# Altium schematic sheet Sheet1.SchDoc(Sheet1)
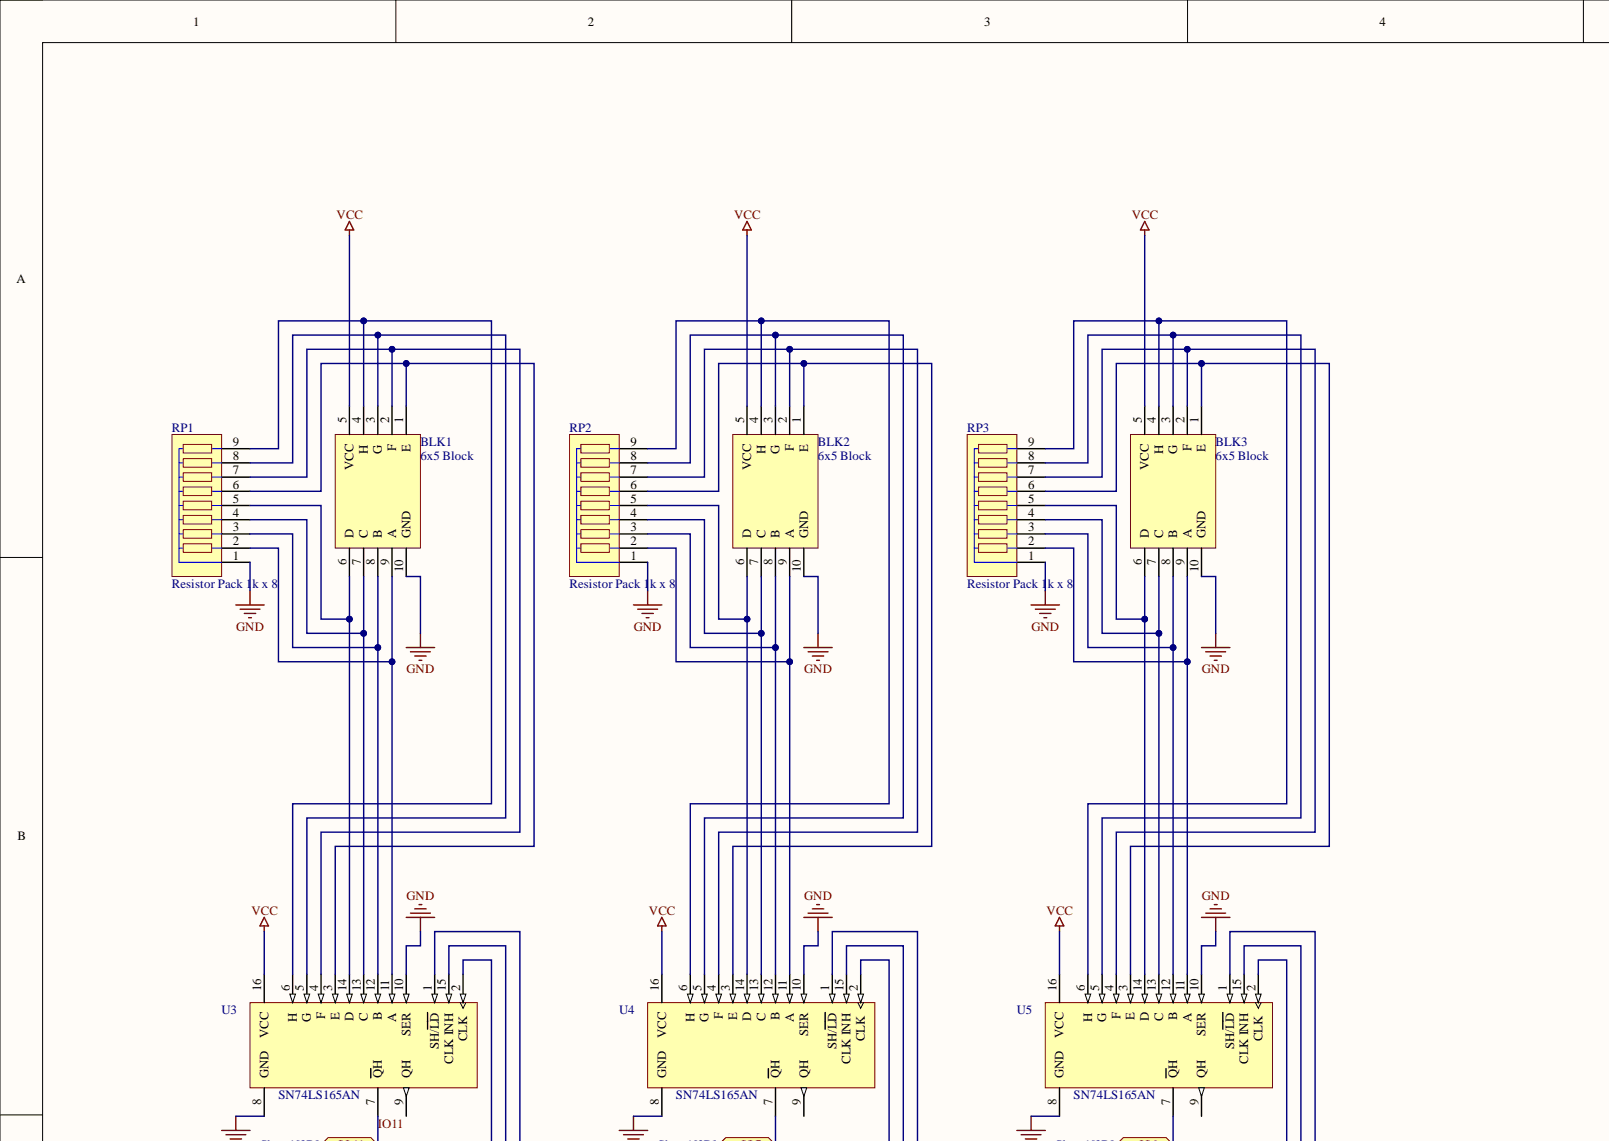
[diagram p0: Sheet1.SchDoc, top left]
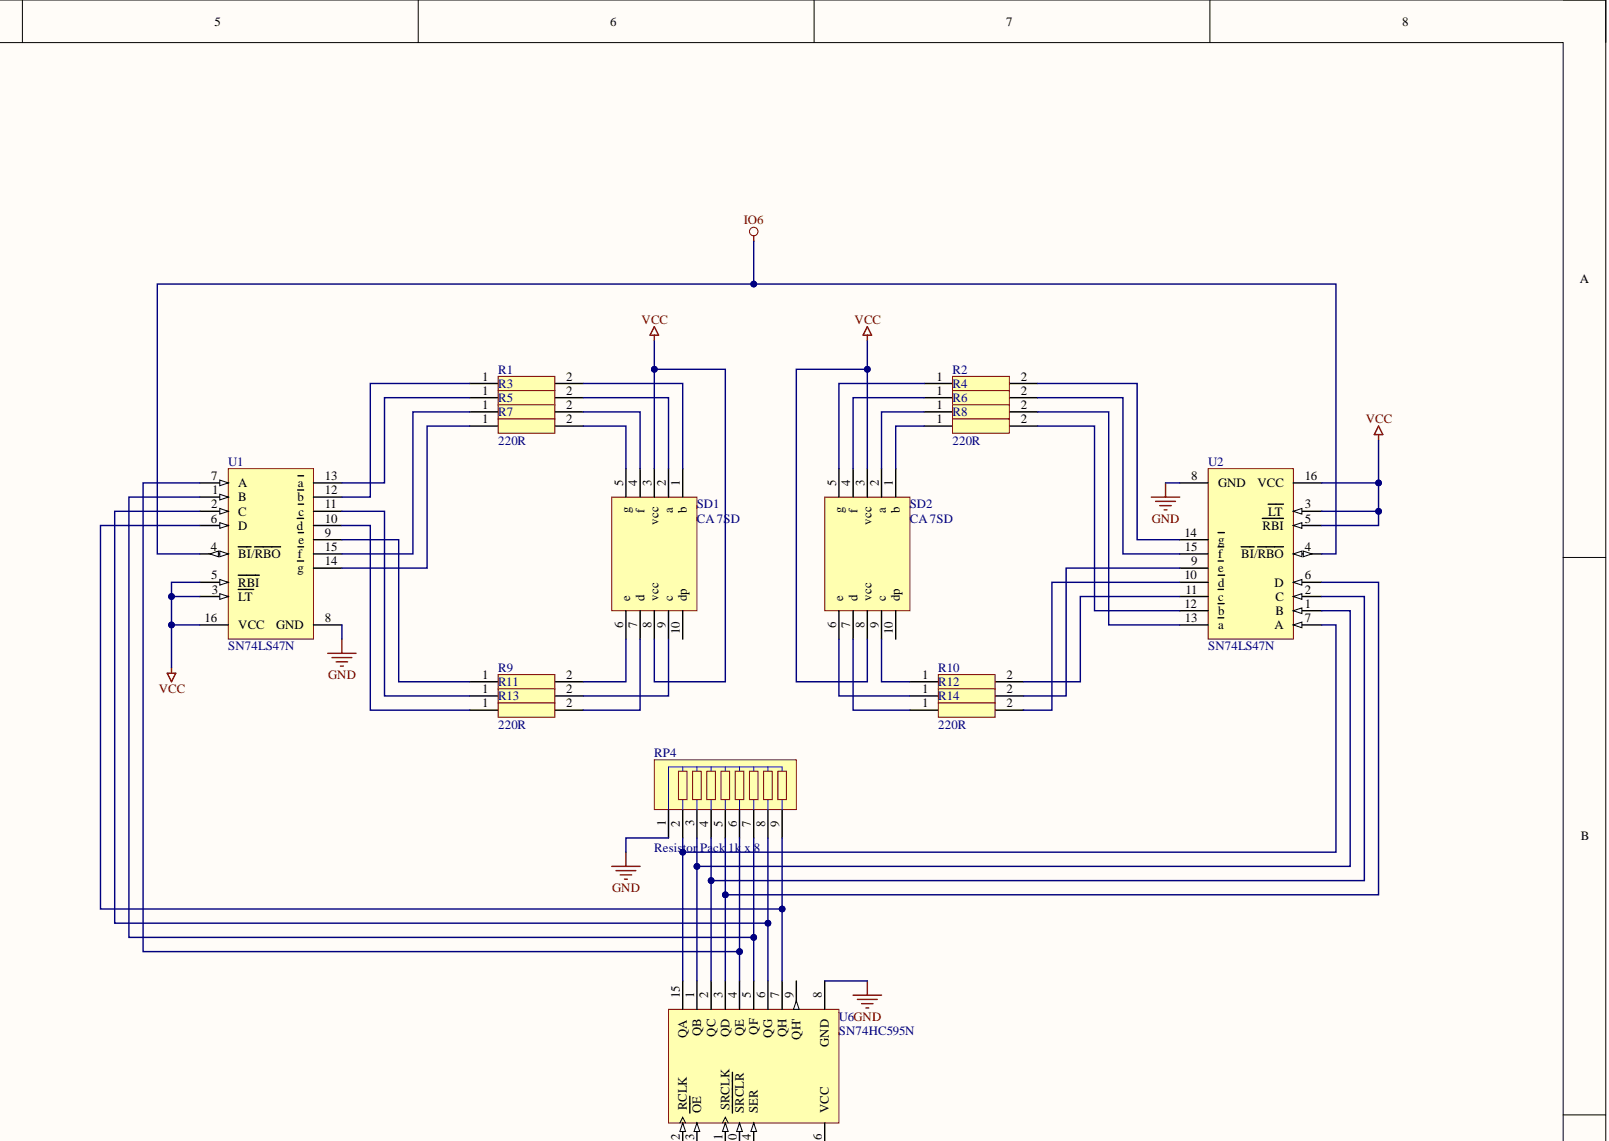
[diagram p1: Sheet1.SchDoc, top right]
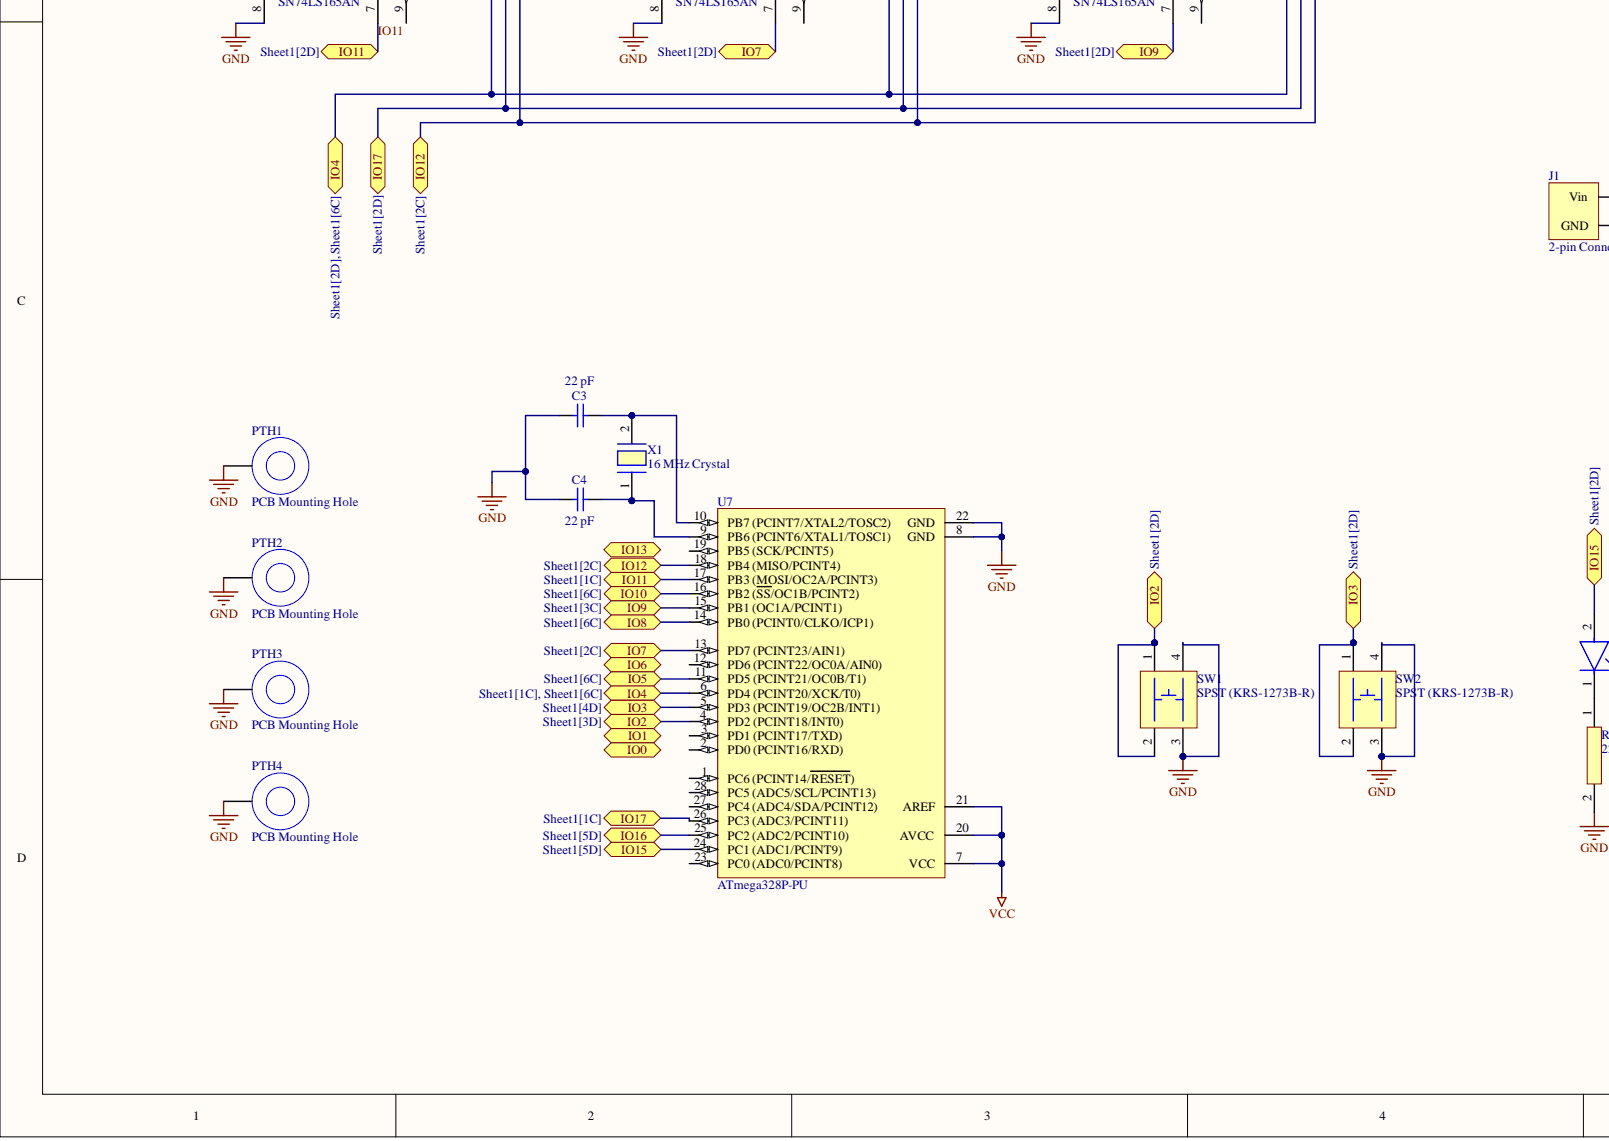
[diagram p2: Sheet1.SchDoc, bottom left]
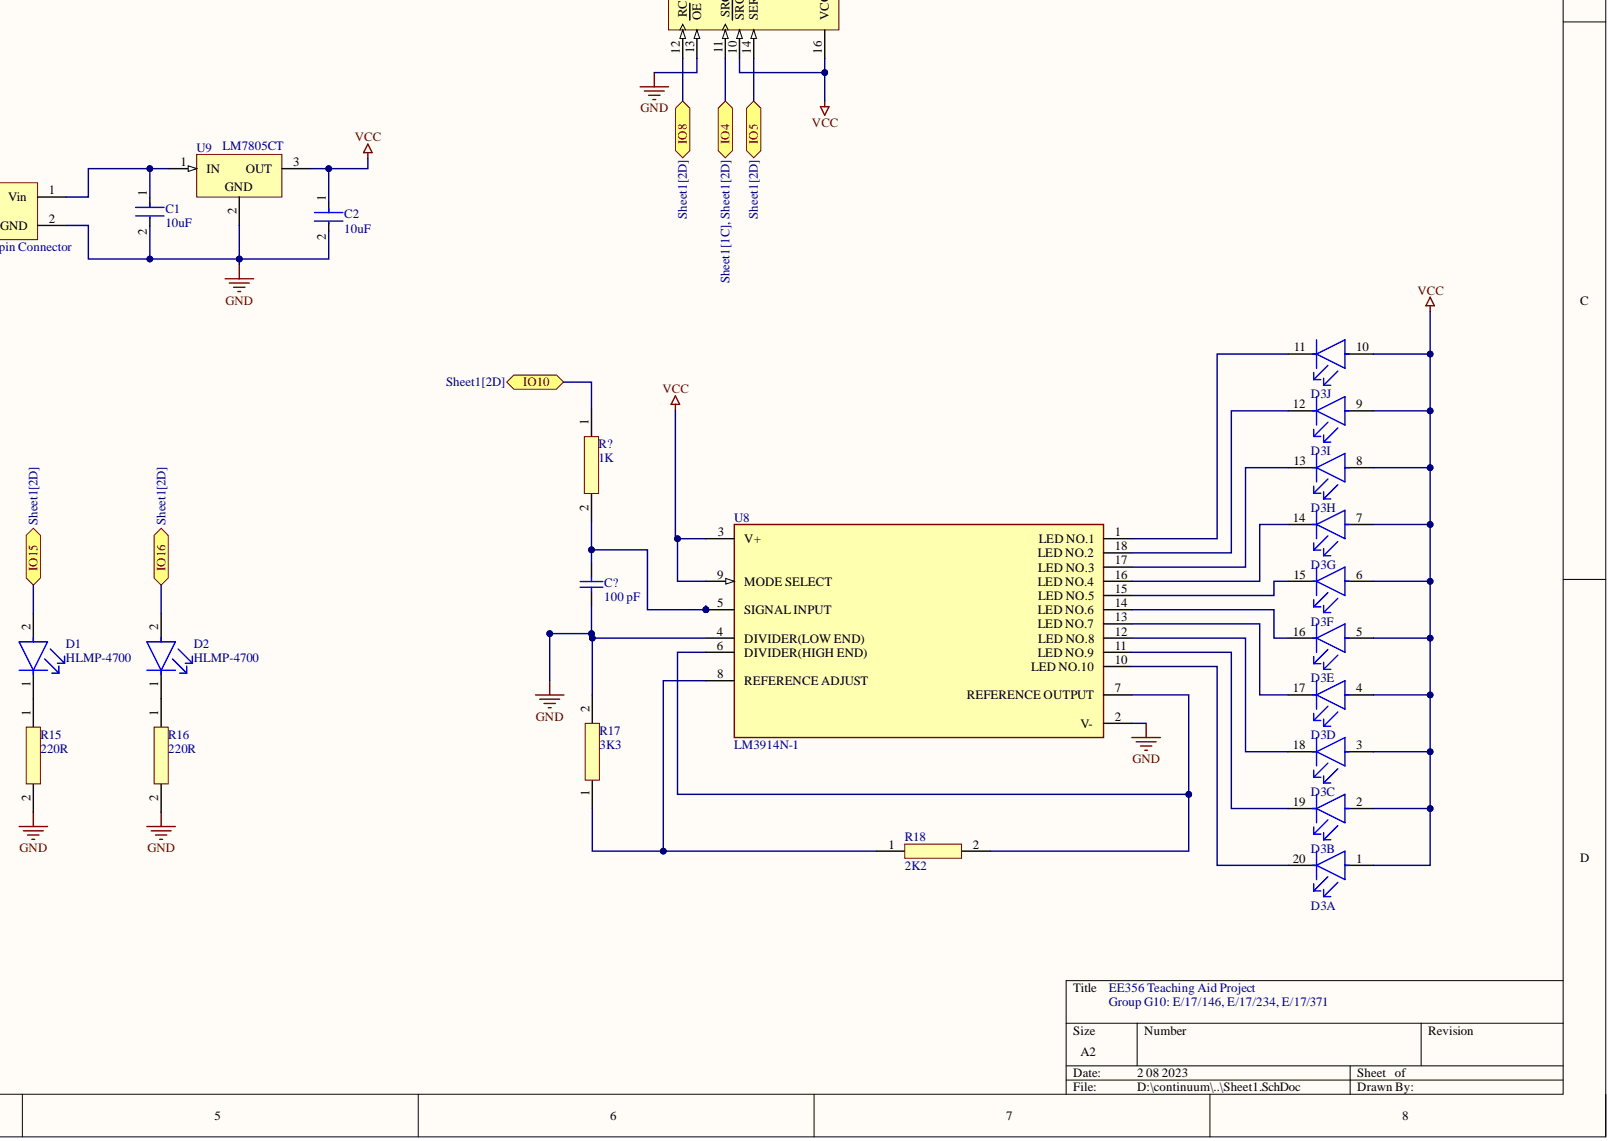
[diagram p3: Sheet1.SchDoc, bottom right]

## Parts
BLK1  6x5 Block  [Block_6x5]
BLK2  6x5 Block  [Block_6x5]
BLK3  6x5 Block  [Block_6x5]
C1  10uF  [FP-RCE3DB-MFG]
C2  10uF  [FP-RCE3DB-MFG]
C3  22 pF  [KEMT-C315-THT-2]
C4  22 pF  [KEMT-C315-THT-2]
C?  100 pF  [KEMT-C315-THT-2]
D1  HLMP-4700  [FP-HLMP-4700-MFG]
D2  HLMP-4700  [FP-HLMP-4700-MFG]
D3  SSA-LXB10HW-GF/LP  [FP-SSA-LXB10HW-GF-LP-MFG]  (value not drawn)  (parts A,B,C,D,E,F,G,H,I,J)
J1  2-pin Connector  [2-pin Connector]
PTH1  PCB Mounting Hole  [PTH 2mm]
PTH2  PCB Mounting Hole  [PTH 2mm]
PTH3  PCB Mounting Hole  [PTH 2mm]
PTH4  PCB Mounting Hole  [PTH 2mm]
R1  220R  [CFR_400mil]
R2  220R  [CFR_400mil]
R3  220R  [CFR_400mil]
R4  220R  [CFR_400mil]
R5  220R  [CFR_400mil]
R6  220R  [CFR_400mil]
R7  220R  [CFR_400mil]
R8  220R  [CFR_400mil]
R9  220R  [CFR_400mil]
R10  220R  [CFR_400mil]
R11  220R  [CFR_400mil]
R12  220R  [CFR_400mil]
R13  220R  [CFR_400mil]
R14  220R  [CFR_400mil]
R15  220R  [CFR_400mil]
R16  220R  [CFR_400mil]
R17  3K3  [CFR_400mil]
R18  2K2  [CFR_400mil]
R?  1K  [CFR_400mil]
RP1  Resistor Pack 1k x 8  [Resistor Pack 1k x 8]
RP2  Resistor Pack 1k x 8  [Resistor Pack 1k x 8]
RP3  Resistor Pack 1k x 8  [Resistor Pack 1k x 8]
RP4  Resistor Pack 1k x 8  [Resistor Pack 1k x 8]
SD1  CA 7SD  [CA 7SD]
SD2  CA 7SD  [CA 7SD]
SW1  SPST (KRS-1273B-R)  Throw Configuration: SPST  [SPST KRS-1273B-R]
SW2  SPST (KRS-1273B-R)  Throw Configuration: SPST  [SPST KRS-1273B-R]
U1  SN74LS47N  [N0016A]
U2  SN74LS47N  [N0016A]
U3  SN74LS165AN  [N0016A]
U4  SN74LS165AN  [N0016A]
U5  SN74LS165AN  [N0016A]
U6  SN74HC595N  [N0016A]
U7  ATmega328P-PU  [28P3]
U8  LM3914N-1  [FP-NFK0018A-MFG]
U9  LM7805CT  [FAIR-TO-220-3]
X1  16 MHz Crystal  [Crystal Osc TH]

## Ports
IO0, IO1, IO2, IO3, IO4, IO5, IO6, IO7, IO8, IO9, IO10, IO11, IO12, IO13, IO15, IO16, IO17

## Nets
GND: BLK1.10(GND) BLK2.10(GND) BLK3.10(GND) C1.2 C2.2 C3.1 C4.1 C?.1 J1.2 PTH1.1 PTH2.1 PTH3.1 PTH4.1 R15.2 R16.2 R17.2 RP1.1 RP2.1 RP3.1 RP4.1 SW1.3 SW1.4 SW2.3 SW2.4 U1.8(GND) U2.8(GND) U3.8(GND) U3.10(SER) U4.8(GND) U4.10(SER) U5.8(GND) U5.10(SER) U6.8(GND) U6.13(OE) U7.8(GND) U7.22(GND) U8.2(V-) U8.4(DIVIDER(LOW_END)) U9.2
IO6: U1.4(BI/RBO) U2.4(BI/RBO)
VCC: BLK1.5(VCC) BLK2.5(VCC) BLK3.5(VCC) C2.1 D3.1 D3.2 D3.3 D3.4 D3.5 D3.6 D3.7 D3.8 D3.9 D3.10 SD1.3(vcc) SD1.8(vcc) SD2.3(vcc) SD2.8(vcc) U1.3(LT) U1.5(RBI) U1.16(VCC) U2.3(LT) U2.5(RBI) U2.16(VCC) U3.16(VCC) U4.16(VCC) U5.16(VCC) U6.10(SRCLR) U6.16(VCC) U7.7(VCC) U7.20(AVCC) U7.21(AREF) U8.3(V+) U8.9(MODE_SELECT) U9.3
IO2: SW1.1 SW1.2 U7.4(PD2_(PCINT18/INT0)) ; port IO2
IO3: SW2.1 SW2.2 U7.5(PD3_(PCINT19/OC2B/INT1)) ; port IO3
IO4: U3.2(CLK) U4.2(CLK) U5.2(CLK) U6.11(SRCLK) U7.6(PD4_(PCINT20/XCK/T0)) ; port IO4
IO5: U6.14(SER) U7.11(PD5_(PCINT21/OC0B/T1)) ; port IO5
IO7: U4.7(QH) U7.13(PD7_(PCINT23/AIN1)) ; port IO7
IO8: U6.12(RCLK) U7.14(PB0_(PCINT0/CLKO/ICP1)) ; port IO8
IO9: U5.7(QH) U7.15(PB1_(OC1A/PCINT1)) ; port IO9
IO10: R?.1 U7.16(PB2_(SS/OC1B/PCINT2)) ; port IO10
IO11: U3.7(QH) U7.17(PB3_(MOSI/OC2A/PCINT3)) ; port IO11
IO12: U3.1(SH/LD) U4.1(SH/LD) U5.1(SH/LD) U7.18(PB4_(MISO/PCINT4)) ; port IO12
IO15: D1.2 U7.24(PC1_(ADC1/PCINT9)) ; port IO15
IO16: D2.2 U7.25(PC2_(ADC2/PCINT10)) ; port IO16
IO17: U3.15(CLK_INH) U4.15(CLK_INH) U5.15(CLK_INH) U7.26(PC3_(ADC3/PCINT11)) ; port IO17
NetBLK1_1: BLK1.1(E) RP1.6 U3.3(E)
NetBLK1_2: BLK1.2(F) RP1.7 U3.4(F)
NetBLK1_3: BLK1.3(G) RP1.8 U3.5(G)
NetBLK1_4: BLK1.4(H) RP1.9 U3.6(H)
NetBLK1_6: BLK1.6(D) RP1.5 U3.14(D)
NetBLK1_7: BLK1.7(C) RP1.4 U3.13(C)
NetBLK1_8: BLK1.8(B) RP1.3 U3.12(B)
NetBLK1_9: BLK1.9(A) RP1.2 U3.11(A)
NetBLK2_1: BLK2.1(E) RP2.6 U4.3(E)
NetBLK2_2: BLK2.2(F) RP2.7 U4.4(F)
NetBLK2_3: BLK2.3(G) RP2.8 U4.5(G)
NetBLK2_4: BLK2.4(H) RP2.9 U4.6(H)
NetBLK2_6: BLK2.6(D) RP2.5 U4.14(D)
NetBLK2_7: BLK2.7(C) RP2.4 U4.13(C)
NetBLK2_8: BLK2.8(B) RP2.3 U4.12(B)
NetBLK2_9: BLK2.9(A) RP2.2 U4.11(A)
NetBLK3_1: BLK3.1(E) RP3.6 U5.3(E)
NetBLK3_2: BLK3.2(F) RP3.7 U5.4(F)
NetBLK3_3: BLK3.3(G) RP3.8 U5.5(G)
NetBLK3_4: BLK3.4(H) RP3.9 U5.6(H)
NetBLK3_6: BLK3.6(D) RP3.5 U5.14(D)
NetBLK3_7: BLK3.7(C) RP3.4 U5.13(C)
NetBLK3_8: BLK3.8(B) RP3.3 U5.12(B)
NetBLK3_9: BLK3.9(A) RP3.2 U5.11(A)
NetC1_1: C1.1 J1.1 U9.1
NetC3_2: C3.2 U7.10(PB7_(PCINT7/XTAL2/TOSC2)) X1.2
NetC4_2: C4.2 U7.9(PB6_(PCINT6/XTAL1/TOSC1)) X1.1
NetC?_2: C?.2 R?.2 U8.5(SIGNAL_INPUT)
NetD1_1: D1.1 R15.1
NetD2_1: D2.1 R16.1
NetD3_11: D3.11 U8.1(LED_NO.1)
NetD3_12: D3.12 U8.18(LED_NO.2)
NetD3_13: D3.13 U8.17(LED_NO.3)
NetD3_14: D3.14 U8.16(LED_NO.4)
NetD3_15: D3.15 U8.15(LED_NO.5)
NetD3_16: D3.16 U8.14(LED_NO.6)
NetD3_17: D3.17 U8.13(LED_NO.7)
NetD3_18: D3.18 U8.12(LED_NO.8)
NetD3_19: D3.19 U8.11(LED_NO.9)
NetD3_20: D3.20 U8.10(LED_NO.10)
NetR1_1: R1.1 U1.12(b)
NetR1_2: R1.2 SD1.1(b)
NetR2_1: R2.1 SD2.5(g)
NetR2_2: R2.2 U2.14(g)
NetR3_1: R3.1 U1.13(a)
NetR3_2: R3.2 SD1.2(a)
NetR4_1: R4.1 SD2.4(f)
NetR4_2: R4.2 U2.15(f)
NetR5_1: R5.1 U1.15(f)
NetR5_2: R5.2 SD1.4(f)
NetR6_1: R6.1 SD2.2(a)
NetR6_2: R6.2 U2.13(a)
NetR7_1: R7.1 U1.14(g)
NetR7_2: R7.2 SD1.5(g)
NetR8_1: R8.1 SD2.1(b)
NetR8_2: R8.2 U2.12(b)
NetR9_1: R9.1 U1.9(e)
NetR9_2: R9.2 SD1.6(e)
NetR10_1: R10.1 SD2.9(c)
NetR10_2: R10.2 U2.11(c)
NetR11_1: R11.1 U1.11(c)
NetR11_2: R11.2 SD1.9(c)
NetR12_1: R12.1 SD2.6(e)
NetR12_2: R12.2 U2.9(e)
NetR13_1: R13.1 U1.10(d)
NetR13_2: R13.2 SD1.7(d)
NetR14_1: R14.1 SD2.7(d)
NetR14_2: R14.2 U2.10(d)
NetR17_1: R17.1 R18.1 U8.8(REFERENCE_ADJUST)
NetR18_2: R18.2 U8.6(DIVIDER(HIGH_END)) U8.7(REFERENCE_OUTPUT)
NetRP4_2: RP4.2 U2.7(A) U6.15(QA)
NetRP4_3: RP4.3 U2.1(B) U6.1(QB)
NetRP4_4: RP4.4 U2.2(C) U6.2(QC)
NetRP4_5: RP4.5 U2.6(D) U6.3(QD)
NetRP4_6: RP4.6 U1.7(A) U6.4(QE)
NetRP4_7: RP4.7 U1.1(B) U6.5(QF)
NetRP4_8: RP4.8 U1.2(C) U6.6(QG)
NetRP4_9: RP4.9 U1.6(D) U6.7(QH)
N00001: ; port IO13
N00002: ; port IO1
N00003: ; port IO0
N00004: ; port IO6

Not connected: SD1 10(dp); SD2 10(dp); U3 9(QH); U4 9(QH); U5 9(QH); U6 9(QH'); U7 1(PC6_(PCINT14/RESET)) 2(PD0_(PCINT16/RXD)) 3(PD1_(PCINT17/TXD)) 12(PD6_(PCINT22/OC0A/AIN0)) 19(PB5_(SCK/PCINT5)) 23(PC0_(ADC0/PCINT8)) 27(PC4_(ADC4/SDA/PCINT12)) 28(PC5_(ADC5/SCL/PCINT13))

## Library data of the parts
BLK1-BLK3 = Block_6x5: Component Kind: Standard; Library Name: Schlib1.SchLib
C1 C2 = CMP-06035-033894-1: Cap Ceramic 10uF 25V X7R ±10% Radial 2.5mm +125°C Automotive Bulk (RCER71E106K3A2H03B); Lead Diameter: 0.5mm; Lead/Base Style: Straight; Capacitance: 10uF; Case/Package: Radial; Component Kind: Standard; Dielectric: X7R; Height: 5mm; Height - Seated (Max): 0.197inch; Lead Pitch: 0.098inch; Length: 0.217inch; Library Name: Altium Content Vault; Max Operating Temperature: 125°C; Min Operating Temperature: -55°C; Mount: Through Hole; Package Quantity: 500; Packaging: Bulk; Ratings: AEC-Q200; Resistor Type: Ceramic; Termination: Radial; Tolerance: 10%; Voltage Rating: 25V; Voltage Rating (DC): 25V; Width: 0.157inch
C3 C4 = CMP-1664-00008-1: Capacitor, Ceramic, 22 pF, +/-10 %, 100 V, -55 to 125 degC, 2-Pin THD, RoHS, Bulk; Case\Package: TO-220; Max Input Voltage: 40 V; Max Ouput Current: 1.5 A; Min Input Voltage: 4.2 V; Output Voltage: 1.2 V; Outputs: 1; Component Kind: Standard; ComponentLink1Description: Manufacturer URL; ComponentLink1URL: http://www.kemet.com/; ComponentLink2Description: Datasheet; ComponentLink2URL: http://capacitoredge.kemet.com/capedge2/DataSheet/Datasheet-C315C220K1G5TA.pdf?pn=C315C220K1G5TA{BULK}; DatasheetVersion: Aug-16; Height: 9.28 mm; Length: 10.28 mm; Library Name: Altium Content Vault; Max Operating Temperature: 125 degC; Min Operating Temperature: 0 degC; Mount: Through Hole; Output Current: 1.5 A; Package Quantity: 50; PackageDescription: 2-Pin Through Hole Device, Body 3.81 x 2.54 mm; PackageReference: C315-THT-2; Packaging: Rail/Tube; Pins: 3; Radiation Hardening: No; REACH SVHC: No SVHC; RoHSCompliant: true; Weight: 0.08113 oz; Width: 4.82 mm
C? = CMP-1664-00008-1: Capacitor, Ceramic, 100 pF, +/-10 %, 100 V, -55 to 125 degC, 2-Pin THD, RoHS, Bulk; Case\Package: TO-220; Max Input Voltage: 40 V; Max Ouput Current: 1.5 A; Min Input Voltage: 4.2 V; Output Voltage: 1.2 V; Outputs: 1; Component Kind: Standard; ComponentLink1Description: Manufacturer URL; ComponentLink1URL: http://www.kemet.com/; ComponentLink2Description: Datasheet; ComponentLink2URL: http://capacitoredge.kemet.com/capedge2/DataSheet/Datasheet-C315C220K1G5TA.pdf?pn=C315C220K1G5TA{BULK}; DatasheetVersion: Aug-16; Height: 9.28 mm; Length: 10.28 mm; Library Name: Altium Content Vault; Max Operating Temperature: 125 degC; Min Operating Temperature: 0 degC; Mount: Through Hole; Output Current: 1.5 A; Package Quantity: 50; PackageDescription: 2-Pin Through Hole Device, Body 3.81 x 2.54 mm; PackageReference: C315-THT-2; Packaging: Rail/Tube; Pins: 3; Radiation Hardening: No; REACH SVHC: No SVHC; RoHSCompliant: true; Weight: 0.08113 oz; Width: 4.82 mm
D1 D2 = CMP-18399-000008-1: LED RED DIFFUSED T-1 3/4 T/H; Manufacturer: Broadcom; Forward Current: 2mA; Forward Voltage: 1.7V; Led Orientation: Straight; Luminous Intensity: 2.3mcd; Peak Emmision Wavelength: 635nm; Power Dissipation: 14mW; Reverse Voltage: 5V; Viewing Angle: 50°; Wavelength: 626nm; Automotive: No; Category: Diode; Colour: Red; Component Kind: Standard; ComponentLink2Description: Datasheet; ComponentLink2URL: https://docs.broadcom.com/docs/AV02-1557EN; Device Class L1: Optoelectronics; Device Class L2: LEDs; Device Class L3: unset; Digikey Description: LED RED DIFFUSED T-1 3/4 T/H; Lead Free: Yes; Library Name: Altium Content Vault; Manufacturer Part Number: HLMP-4700; Mouser Description: LED; Red; 2.3mcd; 0.24In.Dia.; T-1-3/4; 500mA; 1.7V; 635nm; 50deg; 5V; 24mW; -55degC;; Octopart: https://octopart.com/hlmp-4700-broadcom-71130526; Package: HLMP-4700; RoHS: TRUE; Temperature: -40°C to +100°C; Temperature Range High: +100°C; Temperature Range Low: -40°C
D3 = CMP-57188-000001-1: LED ARRAY 10X25MM 10SEG RED DIFF; Manufacturer: lumex; Display Type: Bar Graph; Forward Current: 20mA; Forward Voltage: 2V; Luminous Intensity: 500mcd; Power Dissipation: 105mW; Reverse Voltage: 5V; Viewing Angle: 160°; Automotive: No; Category: Diode; Component Kind: Standard; ComponentLink2Description: Datasheet; ComponentLink2URL: https://www.lumex.com/spec/SSA-LXB10HW-GF-LP.pdf; Device Class L1: Optoelectronics; Device Class L2: Displays; Device Class L3: LED Displays; Digikey Description: LED ARRAY 10X25MM 10SEG RED DIFF; Height: 7.05mm; Lead Free: Yes; Library Name: Altium Content Vault; Manufacturer Part Number: SSA-LXB10HW-GF/LP; Mouser Description: LED Bars and Arrays 10 Segment Red; Octopart: https://octopart.com/ssa-lxb10hw-gf%2Flp-lumex-548235; Package: SSA-LXB10HW-GF/LP; RoHS: TRUE; Temperature: -40°C to +85°C; Temperature Range High: +85°C; Temperature Range Low: -40°C; Test Current: 20mA
J1 = PPTC021LFBN-RC: Female, 2C, Straight, .100"CC; 3.20mm Tail, No Mt. 100u" Tin; PBT, Phos Bronze, 105 C; Manufacturer: Sullins; Connector Type: Header; Number of Positions: 2; Pitch: 0.1inch; Category: Connectors; Color: Black; Component Kind: Standard; ComponentLink1Description: https://media.digikey.com/pdf/Data%20Sheets/Sullins%20PDFs/Female_Headers.100_DS.pdf?src-supplier=Digi-Key; ComponentLink1URL: https://media.digikey.com/pdf/Data%20Sheets/Sullins%20PDFs/Female_Headers.100_DS.pdf?src-supplier=Digi-Key; ComponentLink2Description: http://www.sullinscorp.com/catalogs/78_Page80-81_HEADER_.100_2.54mm_FEMALE.pdf?src-supplier=Future+Electronics; ComponentLink2URL: http://www.sullinscorp.com/catalogs/78_Page80-81_HEADER_.100_2.54mm_FEMALE.pdf?src-supplier=Future+Electronics; Contact Material: Bronze; Contact Plating: Tin; Contact Type: Female Socket; Height: 8.5mm; Housing Material: Plastic; Lead Free: Lead Free; Length: 5.58mm; Library Name: Schlib1.SchLib; Manufacturer Part Number: PPTC021LFBN-RC; Mount: Through Hole; Number of Rows: 1; Package Quantity: 1000; Packaging: Bulk; Pricing 1: 1=0.32000, 10=0.27500, 100=0.21390, 500=0.18332, 1000=0.15278, 5000=0.13095, 10000=0.12610 (USD); RoHS: Yes; RoHS Compliant: Yes; Stock 1: 86332; Supplier 1: Digi-Key; Supplier Part Number 1: S7000-ND; Termination: Solder; Width: 2.5mm
PTH1-PTH4 = PTH: Component Kind: Standard; Library Name: Schlib1.SchLib
R1-R16 = CFR-25JB-52-220R: General Type Carbon Film Resistor 220Ohm 1/4W 5% Axial Bulk; Manufacturer: Yageo; Max Power Dissipation: 250mW; Temperature Coefficient: 500ppm/°C; Case/Package: Axial; Category: Through-Hole Resistors; Component Kind: Standard; ComponentLink1Description: http://www.mouser.com/ds/2/447/Yageo%20LR_CFR_2013-540723.pdf?src-supplier=Mouser; ComponentLink1URL: http://www.mouser.com/ds/2/447/Yageo%20LR_CFR_2013-540723.pdf?src-supplier=Mouser; ComponentLink2Description: http://www.yageo.com/NewPortal/yageodocoutput?fileName=/pdf/throughhole/Yageo_LR_CFR_2013.pdf&src-supplier=Digi-Key; ComponentLink2URL: http://www.yageo.com/NewPortal/yageodocoutput?fileName=/pdf/throughhole/Yageo_LR_CFR_2013.pdf&src-supplier=Digi-Key; Length: 0.248inch; Library Name: Schlib1.SchLib; Manufacturer Part Number: CFR-25JB-52-220R; Max Operating Temperature: 155°C; Min Operating Temperature: -55°C; Number of Terminations: 2; Package Quantity: 200; Packaging: Bulk; Pricing 1: 1=0.10000, 10=0.06800, 25=0.04680, 50=0.03640, 100=0.02600, 250=0.02028, 500=0.01612, 1000=0.01144, 5000=0.00884 (USD); Resistance: 220R; Resistor Type: Carbon Film; RoHS: Yes; RoHS Compliant: Yes; Series: Carbon Film; Stock 1: 1583; Supplier 1: Digi-Key; Supplier Part Number 1: 220QBK-ND; Tolerance: 5%
R17 = CFR-25JB-52-3K3: General Type Carbon Film Resistor 3.3kOhm 1/4W 5% Axial Bulk; Manufacturer: Yageo; Manufacturer Part Number: CFR-25JB-52-220R; Max Power Dissipation: 250mW; Resistance: 220R; Temperature Coefficient: 500ppm/°C; Case/Package: Axial; Category: Through-Hole Resistors; Component Kind: Standard; ComponentLink1Description: http://www.mouser.com/ds/2/447/Yageo%20LR_CFR_2013-540723.pdf?src-supplier=Mouser; ComponentLink1URL: http://www.mouser.com/ds/2/447/Yageo%20LR_CFR_2013-540723.pdf?src-supplier=Mouser; ComponentLink2Description: http://www.yageo.com/NewPortal/yageodocoutput?fileName=/pdf/throughhole/Yageo_LR_CFR_2013.pdf&src-supplier=Digi-Key; ComponentLink2URL: http://www.yageo.com/NewPortal/yageodocoutput?fileName=/pdf/throughhole/Yageo_LR_CFR_2013.pdf&src-supplier=Digi-Key; Length: 0.248inch; Library Name: Schlib1.SchLib; Max Operating Temperature: 155°C; Min Operating Temperature: -55°C; Number of Terminations: 2; Package Quantity: 200; Packaging: Bulk; Pricing 1: 1=0.10000, 10=0.06800, 25=0.04680, 50=0.03640, 100=0.02600, 250=0.02028, 500=0.01612, 1000=0.01144, 5000=0.00884 (USD); Resistor Type: Carbon Film; RoHS: Yes; RoHS Compliant: Yes; Series: Carbon Film; Stock 1: 1583; Supplier 1: Digi-Key; Supplier Part Number 1: 220QBK-ND; Tolerance: 5%
R18 = CFR-25JB-52-2K2: General Type Carbon Film Resistor 2.2kOhm 1/4W 5% Axial Bulk; Manufacturer: Yageo; Manufacturer Part Number: CFR-25JB-52-220R; Max Power Dissipation: 250mW; Resistance: 220R; Temperature Coefficient: 500ppm/°C; Case/Package: Axial; Category: Through-Hole Resistors; Component Kind: Standard; ComponentLink1Description: http://www.mouser.com/ds/2/447/Yageo%20LR_CFR_2013-540723.pdf?src-supplier=Mouser; ComponentLink1URL: http://www.mouser.com/ds/2/447/Yageo%20LR_CFR_2013-540723.pdf?src-supplier=Mouser; ComponentLink2Description: http://www.yageo.com/NewPortal/yageodocoutput?fileName=/pdf/throughhole/Yageo_LR_CFR_2013.pdf&src-supplier=Digi-Key; ComponentLink2URL: http://www.yageo.com/NewPortal/yageodocoutput?fileName=/pdf/throughhole/Yageo_LR_CFR_2013.pdf&src-supplier=Digi-Key; Length: 0.248inch; Library Name: Schlib1.SchLib; Max Operating Temperature: 155°C; Min Operating Temperature: -55°C; Number of Terminations: 2; Package Quantity: 200; Packaging: Bulk; Pricing 1: 1=0.10000, 10=0.06800, 25=0.04680, 50=0.03640, 100=0.02600, 250=0.02028, 500=0.01612, 1000=0.01144, 5000=0.00884 (USD); Resistor Type: Carbon Film; RoHS: Yes; RoHS Compliant: Yes; Series: Carbon Film; Stock 1: 1583; Supplier 1: Digi-Key; Supplier Part Number 1: 220QBK-ND; Tolerance: 5%
R? = CFR-25JB-52-3K3: General Type Carbon Film Resistor 1kOhm 1/4W 5% Axial Bulk; Manufacturer: Yageo; Manufacturer Part Number: CFR-25JB-52-220R; Max Power Dissipation: 250mW; Resistance: 220R; Temperature Coefficient: 500ppm/°C; Case/Package: Axial; Category: Through-Hole Resistors; Component Kind: Standard; ComponentLink1Description: http://www.mouser.com/ds/2/447/Yageo%20LR_CFR_2013-540723.pdf?src-supplier=Mouser; ComponentLink1URL: http://www.mouser.com/ds/2/447/Yageo%20LR_CFR_2013-540723.pdf?src-supplier=Mouser; ComponentLink2Description: http://www.yageo.com/NewPortal/yageodocoutput?fileName=/pdf/throughhole/Yageo_LR_CFR_2013.pdf&src-supplier=Digi-Key; ComponentLink2URL: http://www.yageo.com/NewPortal/yageodocoutput?fileName=/pdf/throughhole/Yageo_LR_CFR_2013.pdf&src-supplier=Digi-Key; Length: 0.248inch; Library Name: Schlib1.SchLib; Max Operating Temperature: 155°C; Min Operating Temperature: -55°C; Number of Terminations: 2; Package Quantity: 200; Packaging: Bulk; Pricing 1: 1=0.10000, 10=0.06800, 25=0.04680, 50=0.03640, 100=0.02600, 250=0.02028, 500=0.01612, 1000=0.01144, 5000=0.00884 (USD); Resistor Type: Carbon Film; RoHS: Yes; RoHS Compliant: Yes; Series: Carbon Film; Stock 1: 1583; Supplier 1: Digi-Key; Supplier Part Number 1: 220QBK-ND; Tolerance: 5%
RP1-RP4 = 4309R-101-102LF: Res Thick Film NET 1K Ohm 2% 1.13W ±100ppm/°C BUS Molded 9-Pin SIP Pin Thru-Hole; Manufacturer: Bourns; Circuit Type: Bussed; Number of Elements: 8; Number of Terminals/Pins: 9; Power Per Element: 200mW; Resistance: 1kR; Voltage Rating: 100V; Case/Package: SIP; Category: Resistor Arrays; Component Kind: Standard; ComponentLink1Description: https://datasheet.ciiva.com/1132/1639105-1132861.pdf?src-supplier=Element14; ComponentLink1URL: https://datasheet.ciiva.com/1132/1639105-1132861.pdf?src-supplier=Element14; ComponentLink2Description: https://datasheet.ciiva.com/3264/4300r-3264093.pdf?src-supplier=Avnet; ComponentLink2URL: https://datasheet.ciiva.com/3264/4300r-3264093.pdf?src-supplier=Avnet; ComponentLink3Description: https://datasheet.ciiva.com/6494/4300r-6494366.pdf?src-supplier=Digi-Key; ComponentLink3URL: https://datasheet.ciiva.com/6494/4300r-6494366.pdf?src-supplier=Digi-Key; ComponentLink4Description: https://datasheet.ciiva.com/14583/70154247-14583487.pdf?src-supplier=Allied+Electronics; ComponentLink4URL: https://datasheet.ciiva.com/14583/70154247-14583487.pdf?src-supplier=Allied+Electronics; Depth: 2.16mm; Height: 0.195inch; Lead Pitch: 2.54mm; Length: 0.884inch; Library Name: Schlib1.SchLib; Manufacturer Part Number: 4309R-101-102LF; Max Operating Temperature: 125°C; Military Standard: Not; Min Operating Temperature: -55°C; Mount: Through Hole; Number of Pins: 9; Number of Resistors: 8; Package Quantity: 22; Packaging: Rail/Tube; Power Rating: 1.13W; Pricing 1: 1=1.42000 (USD); Radiation Hardening: No; Reach SVHC Compliant: Yes; Resistance Tolerance: 2%; RoHS: Yes; RoHS Compliant: Yes; Series: 4300R; Stock 1: 21; Supplier 1: Avnet; Supplier Part Number 1: 32K9075; Technology: THICK FILM; Temperature Coefficient: 100ppm/°C; Terminal Pitch: 2.54mm; Termination: SIP; Tolerance: 2%; Voltage Rating (DC): 100V; Width: 0.085inch
SD1 SD2 = LDS-A5642RI: Single Digit Seven Segment Green 0.56" Common Anode Through Hole LED Display; Manufacturer: Lumex; Forward Current: 10mA; Forward Voltage: 2.2V; Luminous Intensity: 3.9mcd; Max Power Dissipation: 105mW; Number of Contacts: 10; Number of LEDs: 8; Viewing Area (height): 14.2mm; Wavelength: 565nm; Case/Package: DIP; Category: LED Displays; Color: Green; Component Kind: Standard; ComponentLink1Description: https://datasheet.ciiva.com/16294/lds-a5642ri-16294404.pdf?src-supplier=Future+Electronics; ComponentLink1URL: https://datasheet.ciiva.com/16294/lds-a5642ri-16294404.pdf?src-supplier=Future+Electronics; ComponentLink2Description: https://datasheet.ciiva.com/4421/lds-a5642ri-33718-4421941.pdf?src-supplier=Mouser; ComponentLink2URL: https://datasheet.ciiva.com/4421/lds-a5642ri-33718-4421941.pdf?src-supplier=Mouser; ComponentLink3Description: https://datasheet.ciiva.com/30079/lds-a5642ri-30079851.pdf?src-supplier=Onlinecomponents.com; ComponentLink3URL: https://datasheet.ciiva.com/30079/lds-a5642ri-30079851.pdf?src-supplier=Onlinecomponents.com; Display Type: Segment; Element Configuration: Common Anode; Height: 0.32inch; Illumination Color: Green; Lead Free: Lead Free; Length: 0.67inch; Library Name: Schlib1.SchLib; Manufacturer Part Number: LDS-A5642RI; Max Operating Temperature: 85°C; Min Operating Temperature: -40°C; Mount: Through Hole; Number of Pins: 10; Operating Supply Voltage: 2.2V; Package Quantity: 40; Packaging: Bulk; Power Consumption: 105mW; Pricing 1: 1=0.91500, 50=0.88000, 200=0.84000, 750=0.80500, 2500=0.74000 (USD); RoHS: Yes; RoHS Compliant: Yes; Supplier 1: Future Electronics; Supplier Part Number 1: 8628562; Test Current: 10mA; Width: 0.5inch
SW1 SW2 = KRS-1273B-R: Switch Push Button Tactile Off Momentary (On) Single Pole Single Throw Cap: 155389 155409; Manufacturer: Jameco Valuepro; Category: Uncategorized; Component Kind: Standard; ComponentLink1Description: https://datasheet.ciiva.com/19453/155380-19453382.pdf?src-supplier=Jameco; ComponentLink1URL: https://datasheet.ciiva.com/19453/155380-19453382.pdf?src-supplier=Jameco; Library Name: Schlib1.SchLib; Manufacturer Part Number: KRS-1273B-R; Number of Pins: 4; Orientation: Straight; Pricing 1: 1=0.45000, 10=0.39000, 100=0.35000, 250=0.29000 (USD); RoHS: No; Stock 1: 2143; Supplier 1: Jameco; Supplier Part Number 1: 155380; Termination: PC Pins
U1 U2 = CMP-0388-00303-2: BCD-to-7-Segment Decoder / Driver, 4.75 to 5.25 V, 0 to 70 degC, 16-pin DIP (N16), Pb-Free (RoHS); Manufacturer: Texas Instruments; Rating: Catalog; SubFamily: Discrete Segment LED Driver; Vin(Max)(V): 5.25; Vin(Min)(V): 4.75; Code_JEDEC: MS-001; Component Kind: Standard; ComponentLink1Description: Manufacturer URL; ComponentLink1URL: http://www.ti.com/; ComponentLink2Description: Datasheet; ComponentLink2URL: http://www.ti.com/general/docs/lit/getliterature.tsp?genericPartNumber=SN74LS47&fileType=pdf; ComponentLink3Description: Package Specification; ComponentLink3URL: http://www.ti.com/litv/pdf/mpdi002c; DatasheetVersion: SDLS111; Eco-Plan: Pb-Free (RoHS); Library Name: Altium Content Vault; Mounting Technology: Through Hole; PackageDescription: 16-Pin Plastic In-Line, Body 19.305 x 6.35 mm, Pitch 2.54 mm; PackageReference: N0016A; PackageVersion: 4040049/E; PartNumber: SN74LS47N
U3-U5 = CMP-0780-00134-3: Serial-out shift registers, N0016A, TUBE; Manufacturer: Texas Instruments; 3-State Output: No; Bits: 8; F @ Nom Voltage(Max)(Mhz): 35; ICC @ Nom Voltage(Max)(mA): 30; Input Type: TTL; Output Drive (IOL/IOH)(Max)(mA): 8/-0.4; Rating: Catalog; Technology Family: LS; tpd @ Nom Voltage(Max)(ns): 25; VCC(Max)(V): 5.25; VCC(Min)(V): 4.75; Voltage(Nom)(V): 5; Code_JEDEC: MS-001; Component Kind: Standard; DatasheetVersion: SDLS062D; Generic Part Number: SN74LS165A; Library Name: Altium Content Vault; Operating Temperature Range(C): 0 to 70; Output Type: TTL; PackageReference: N0016A; PackageVersion: http://www.ti.com/lit/ml/mpdi002c/mpdi002c.pdf
U6 = CMP-0780-00137-3: 8-Bit Shift Registers With 3-State Output Registers, N0016A, TUBE; Manufacturer: Texas Instruments; 3-State Output: Yes; Bits: 8; F @ Nom Voltage(Max)(Mhz): 28; ICC @ Nom Voltage(Max)(mA): 0.08; Input Type: CMOS; Output Drive (IOL/IOH)(Max)(mA): 7.8/-7.8; Rating: Military; Technology Family: HC; tpd @ Nom Voltage(Max)(ns): 34; VCC(Max)(V): 6; VCC(Min)(V): 2; Code_JEDEC: MS-001; Component Kind: Standard; DatasheetVersion: SCLS041H; Generic Part Number: SN74HC595; Library Name: Altium Content Vault; Operating Temperature Range(C): -40 to 85; Output Type: CMOS; PackageReference: N0016A; PackageVersion: http://www.ti.com/lit/ml/mpdi002c/mpdi002c.pdf; Voltage(Nom)(V): 6
U7 = CMP-0095-00013-2: 8-bit AVR Microcontroller, 32KB Flash, 1KB EEPROM, 2KB SRAM, 28-pin PDIP, Industrial Grade (-40°C to 85°C); Component Kind: Standard; ComponentLink1Description: Manufacturer URL; ComponentLink1URL: http://www.atmel.com/; ComponentLink2Description: ATmega48A/48PA/88A/88PA/168A/168PA/328/328P Datasheet; ComponentLink2URL: http://www.atmel.com/dyn/resources/prod_documents/8271.pdf; ComponentLink3Description: ATmega48A/48PA/88A/88PA/168A/168PA/328/328P Summary; ComponentLink3URL: http://www.atmel.com/dyn/resources/prod_documents/8271S.pdf; DatasheetVersion: revD, May-2011; Library Name: Altium Content Vault; PackageDescription: 28-lead (0.300"/7.62mm Wide) Plastic Dual Inline Package (PDIP); PackageReference: 28P3; PackageVersion: revB, Sep-2001; RevisionNote: DatasheetVersion updated. URLs added.
U8 = CMP-0058-00006-4: IC DRVR DOT/BAR DISPLAY 18DIP; Max Input Voltage: 12V; Max Output Voltage: 1.34V; Max Power Dissipation: 1.365W; Max Supply Current: 9.2kA; Max Supply Voltage: 25V; Min Input Voltage: 1.2V; Nominal Supply Current: 9.2mA; Number of Channels: 10; Operating Supply Current: 6.1mA; Output Current: 30mA; Output Voltage: 1.28V; Case/Package: DIP; Component Kind: Standard; Height: 3.3mm; Lead Free: Contains Lead; Length: 22.86mm; Library Name: Altium Content Vault; Max Operating Temperature: 70°C; Min Operating Temperature: 0°C; Mount: Through Hole; Number of Outputs: 10; Number of Pins: 18; Number of Segments: 10; Package Quantity: 20; Packaging: Rail/Tube; Power Dissipation: 1.365W; Radiation Hardening: No; REACH SVHC: No SVHC; RoHS Compliant: No; Series: -; Supply Current: 9.2mA; Termination: Through Hole; Width: 6.35mm
U9 = CMP-2000-04938-1: Positive Voltage Regulator, 5 V, 1 A, -40 to 125 degC, 3-Pin TO-220, RoHS, Tube; Manufacturer: FairchildSemiconductor; Accuracy: ±4%; Line Regulation: 100mV; Maximum Input Voltage: 35V; Maximum Output Current: 2.2(Typ)A; Maximum Quiescent Current: 8mA; Number of Outputs: 1; Output Type: Fixed; Output Voltage: 5V; Rad Hard: No; Typical Dropout Voltage @ Current: 2@1AV; Category: LinearRegulator; Component Kind: Standard; Datasheet URL: https://datasheet.ciiva.com/26904/lm7805ct-26904988.pdf; Datasheet Version: Aug-12; Library Name: Altium Content Vault; Load Regulation: 100mV; Manufacturer URL: https://www.fairchildsemi.com/; Mounting: ThroughHole; Operating Temperature: -40to125degC; Package: 3TO-220AB; Package Description: 3-Pin Through Hole Device, Body 10 x 4.5 mm, Pitch 2.54 mm; Package Reference: TO-220; Package URL: https://www.fairchildsemi.com/package-drawings/TO/TO220B03.pdf; Packaging: Rail/Tube; Polarity: Positive; Type: Linear
X1 = 9B-16.000MBBK-B: Crystal 16MHz ±50ppm (Tol) ±50ppm (Stability) 20pF FUND 30Ohm 2-Pin DIP HC-49/S Thru-Hole Bulk; Manufacturer: TXC; ESR (Equivalent Series Resistance): 30R; Case/Package: Metal; Category: Crystals; Component Kind: Standard; ComponentLink1Description: https://datasheet.ciiva.com/6733/9b-6733741.pdf?src-supplier=Digi-Key; ComponentLink1URL: https://datasheet.ciiva.com/6733/9b-6733741.pdf?src-supplier=Digi-Key; Depth: 5mm; Frequency: 16MHz; Frequency Stability: 50ppm; Frequency Tolerance: 50ppm; Height: 0.145inch; Length: 0.453inch; Library Name: Schlib1.SchLib; Load Capacitance: 20pF; Manufacturer Part Number: 9B-16.000MBBK-B; Max Operating Temperature: 70°C; Min Operating Temperature: -20°C; Mount: Through Hole; Number of Pins: 2; Package Quantity: 1000; Packaging: Bulk; Pricing 1: 1=0.20360, 10=0.18510, 50=0.17410, 100=0.16200, 500=0.15620, 1000=0.13970 (USD); RoHS: Yes; Stock 1: 932; Supplier 1: Arrow Electronics; Supplier Part Number 1: 9B-16.000MBBK-B; Width: 0.197inch
